# Altium schematic sheet Sheet1_Overview.SchDoc
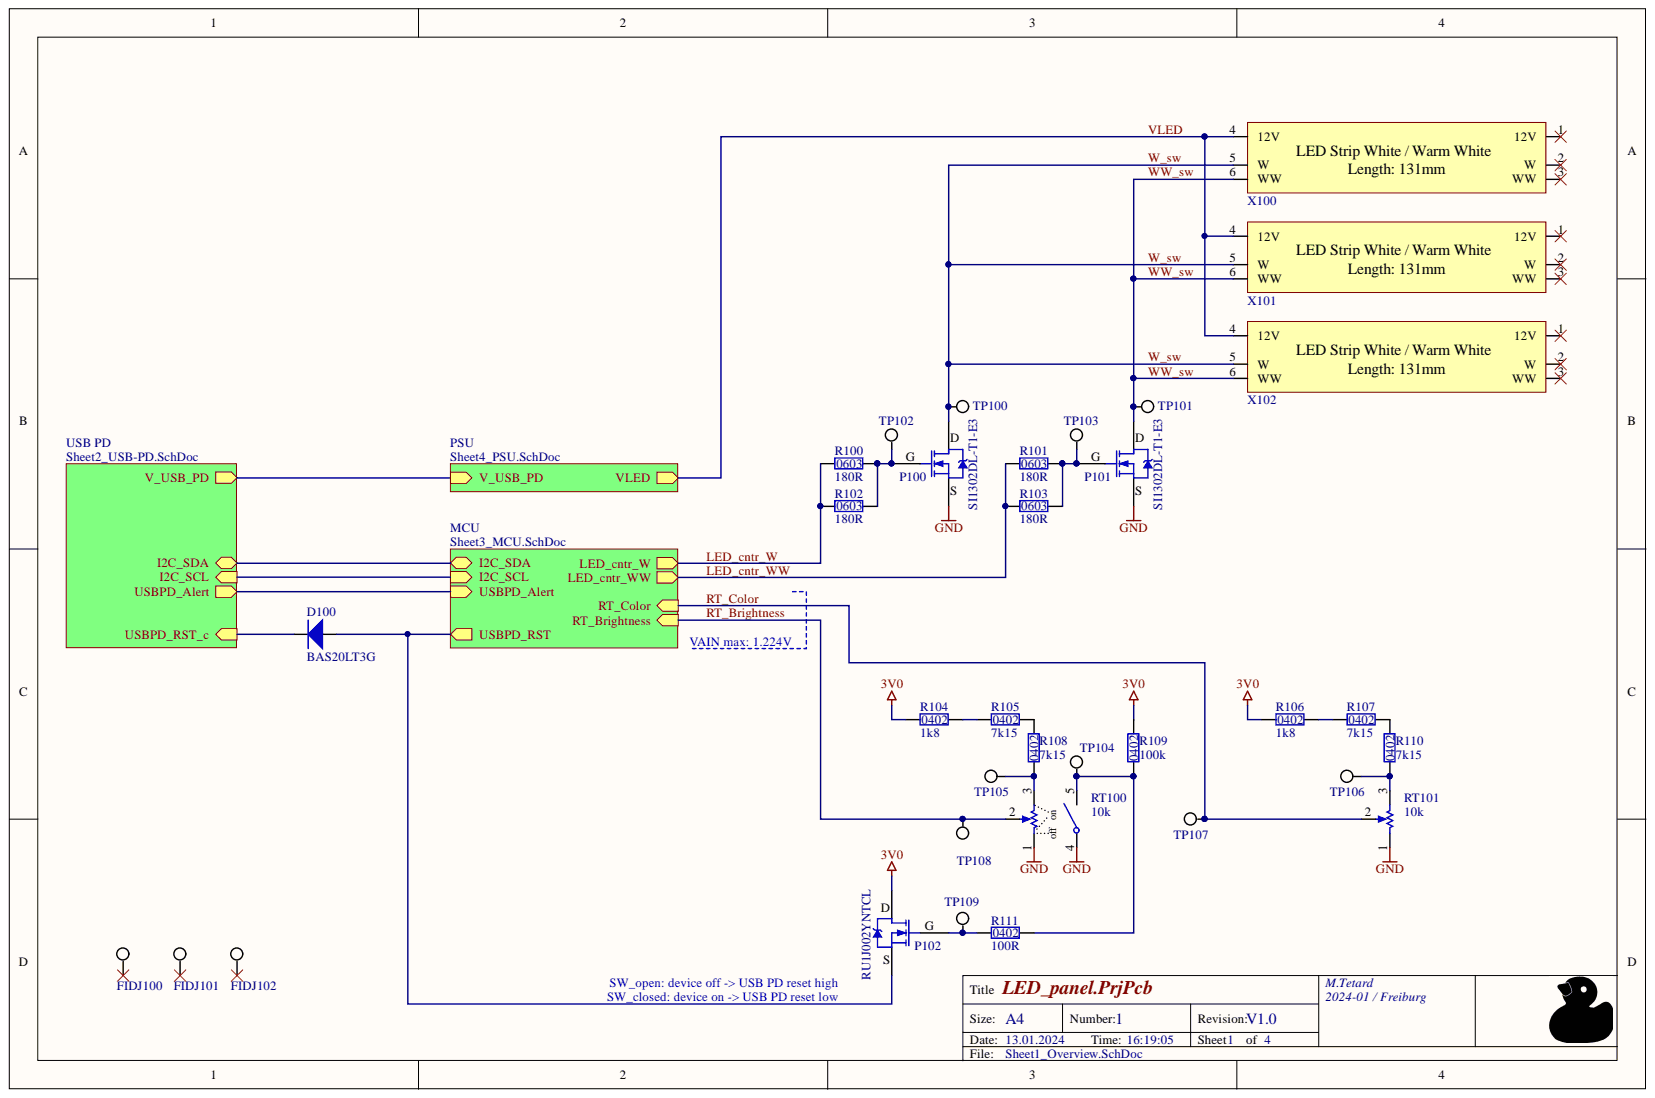
[diagram p0: Sheet1_Overview.SchDoc]

## Parts
D100  BAS20LT3G  [SOT-23-3]
FIDJ100  Fiducial  [FIDJ_1mm]  (value not drawn)
FIDJ101  Fiducial  [FIDJ_1mm]  (value not drawn)
FIDJ102  Fiducial  [FIDJ_1mm]  (value not drawn)
P100  Manufacturer Part Number: SI1302DL-T1-E3  [SOT-323]  (hidden comment: P NMOS 30V 600mA)
P101  Manufacturer Part Number: SI1302DL-T1-E3  [SOT-323]  (hidden comment: P NMOS 30V 600mA)
P102  Manufacturer Part Number: RU1J002YNTCL  [UMT3F]  (hidden comment: P_NCH_50V_150mW)
R100  Resistance: 180R  [0603]  (hidden comment: R 180R 0603)
R101  Resistance: 180R  [0603]  (hidden comment: R 180R 0603)
R102  Resistance: 180R  [0603]  (hidden comment: R 180R 0603)
R103  Resistance: 180R  [0603]  (hidden comment: R 180R 0603)
R104  Resistance: 1k8  [0402]  (hidden comment: R 1k8 0402)
R105  Resistance: 7k15  [0402]  (hidden comment: R 7k15 0402)
R106  Resistance: 1k8  [0402]  (hidden comment: R 1k8 0402)
R107  Resistance: 7k15  [0402]  (hidden comment: R 7k15 0402)
R108  Resistance: 7k15  [0402]  (hidden comment: R 7k15 0402)
R109  Resistance: 100k  [0402]  (hidden comment: R 100k 0402)
R110  Resistance: 7k15  [0402]  (hidden comment: R 7k15 0402)
R111  Resistance: 100R  [0402]  (hidden comment: R 100R 0402)
RT100  10k  [RT_P091S-F*20]
RT101  10k  [RT_P091N-F*25]
TP100  TP  [TP_1mm]
TP101  TP  [TP_1mm]
TP102  TP  [TP_1mm]
TP103  TP  [TP_1mm]
TP104  TP  [TP_1mm]
TP105  TP  [TP_1mm]
TP106  TP  [TP_1mm]
TP107  TP  [TP_1mm]
TP108  TP  [TP_1mm]
TP109  TP  [TP_1mm]
X100  LED Strip  [LED_Strip_COB44-076]
X101  LED Strip  [LED_Strip_COB44-076]
X102  LED Strip  [LED_Strip_COB44-076]

## Nets
3V0: P102.3 R104.2 R106.1 R109.2
GND: P100.2 P101.2 RT100.1 RT100.4 RT101.1
LED_CNTR_W: R100.1 R102.1
LED_CNTR_WW: R101.1 R103.1
RT_BRIGHTNESS: RT100.2 TP108.1
RT_COLOR: RT101.2 TP107.1
VLED: X100.4(12V) X101.4(12V) X102.4(12V)
W_SW: P100.3 TP100.1 X100.5(W) X101.5(W) X102.5(W)
WW_SW: P101.3 TP101.1 X100.6(WW) X101.6(WW) X102.6(WW)
NetD100_1: D100.1 P102.2
NetD100_3: D100.3
NetFIDJ100_1: FIDJ100.1
NetFIDJ101_1: FIDJ101.1
NetFIDJ102_1: FIDJ102.1
NetP100_1: P100.1 R100.2 R102.2 TP102.1
NetP101_1: P101.1 R101.2 R103.2 TP103.1
NetP102_1: P102.1 R111.1 TP109.1
NetR104_1: R104.1 R105.2
NetR105_1: R105.1 R108.2
NetR106_2: R106.2 R107.2
NetR107_1: R107.1 R110.2
NetR108_1: R108.1 RT100.3 TP105.1
NetR109_1: R109.1 R111.2 RT100.5 TP104.1
NetR110_1: R110.1 RT101.3 TP106.1
NetX100_1: X100.1(12V)
NetX100_2: X100.2(W)
NetX100_3: X100.3(WW)
NetX101_1: X101.1(12V)
NetX101_2: X101.2(W)
NetX101_3: X101.3(WW)
NetX102_1: X102.1(12V)
NetX102_2: X102.2(W)
NetX102_3: X102.3(WW)
I2C_SCL:
I2C_SDA:
USBPD_ALERT:
V_USB_PD:

## Library data of the parts
D100 = D_LL_200V_200mA: DIODE GP 200V 200MA SOT23-3; Manufacturer: onsemi; Component Kind: Standard; Library Name: CS_Part_Library.IntLib; Manufacturer Part Number: BAS20LT3G; Supplier 1: Digi-Key; Supplier Part Number 1: BAS20LT3GOSCT-ND; Type: Diode
FIDJ100-FIDJ102 = FIDJ_1mm: Fiducal 1mm; Component Kind: Net Tie; Library Name: CS_Part_Library.IntLib
P100 P101 = P_NCH_30V_280mW: MOSFET N-CH 30V 600MA SC70-3; Manufacturer: Vishay Siliconix; Component Kind: Standard; Library Name: CS_Part_Library.IntLib; Supplier 1: Digi-Key; Supplier Part Number 1: SI1302DL-T1-E3CT-ND; Type: Transistor
P102 = P_NCH_50V_150mW: MOSFET N-CH 50V 200MA UMT3F; Manufacturer: Rohm Semiconductor; Component Kind: Standard; Library Name: CS_Part_Library.IntLib; Supplier 1: Digi-Key; Supplier Part Number 1: RU1J002YNTCLCT-ND; Type: Transistor
R100-R103 = R_180R_0603: RES 180 OHM 1% 1/10W 0603; Manufacturer: Stackpole Electronics Inc; Manufacturer Part Number: RMCF0603FT180R; Component Kind: Standard; Library Name: CS_Part_Library.IntLib; Supplier 1: Digi-Key; Supplier Part Number 1: RMCF0603FT180RCT-ND; Type: Resistor
R104 R106 = R_1k8_0402: RES 1.8K OHM 1% 1/16W 0402; Manufacturer: Stackpole Electronics Inc; Manufacturer Part Number: RMCF0402FT1K80; Component Kind: Standard; Library Name: CS_Part_Library.IntLib; Supplier 1: Digi-Key; Supplier Part Number 1: RMCF0402FT1K80CT-ND; Type: Resistor
R105 R107 R108 R110 = R_7k15_0402: RES 7.15K OHM 1% 1/16W 0402; Manufacturer: Stackpole Electronics Inc; Manufacturer Part Number: RMCF0402FT7K15; Component Kind: Standard; Library Name: CS_Part_Library.IntLib; Supplier 1: Digi-Key; Supplier Part Number 1: RMCF0402FT7K15CT-ND; Type: Resistor
R109 = R_100k_0402: RES 100K OHM 5% 1/16W 0402; Manufacturer: YAGEO; Manufacturer Part Number: RC0402JR-07100KL; Component Kind: Standard; Library Name: CS_Part_Library.IntLib; Supplier 1: Digi-Key; Supplier Part Number 1: 311-100KJRCT-ND; Type: Resistor
R111 = R_100R_0402: RES 100 OHM 1% 1/16W 0402; Manufacturer: Stackpole Electronics Inc; Manufacturer Part Number: RMCF0402FT100R; Component Kind: Standard; Library Name: CS_Part_Library.IntLib; Supplier 1: Digi-Key; Supplier Part Number 1: RMCF0402FT100RCT-ND; Type: Resistor
RT100 = RT_10k_SW_V: POT 10K OHM 1/20W PLASTIC LINEAR; Manufacturer: TT Electronics/BI; Manufacturer Part Number: P091S-FC20BR10K; Component Kind: Standard; Library Name: CS_Part_Library.IntLib; Supplier 1: Digi-Key; Supplier Part Number 1: 987-1283-ND; Type: other, Poti
RT101 = RT_10k_V: POT 10K OHM 1/20W PLASTIC LINEAR; Manufacturer: TT Electronics/BI; Manufacturer Part Number: P091N-FC25BR10K; Component Kind: Standard; Library Name: CS_Part_Library.IntLib; Supplier 1: Digi-Key; Supplier Part Number 1: 987-1279-ND; Type: other, Poti
TP100-TP109 = TP_1mm: Test Point 1mm; Component Kind: Net Tie; Library Name: CS_Part_Library.IntLib
X100-X102 = LED_Strip_2WH_131mm: revoART, 12V COB LED Streifen - einstellbare Farbtemperatur - alle 1,3cm teilbar - 10mm breit; Manufacturer Part Number: COB44-076; Component Kind: Standard; Library Name: CS_Part_Library.IntLib; Manufacturer: revoART; Supplier 1: LED24; Supplier Part Number 1: COB44-076; Type: other
